# Altium schematic sheet Schem, Transadmittance PWR_rev_D2.SchDoc
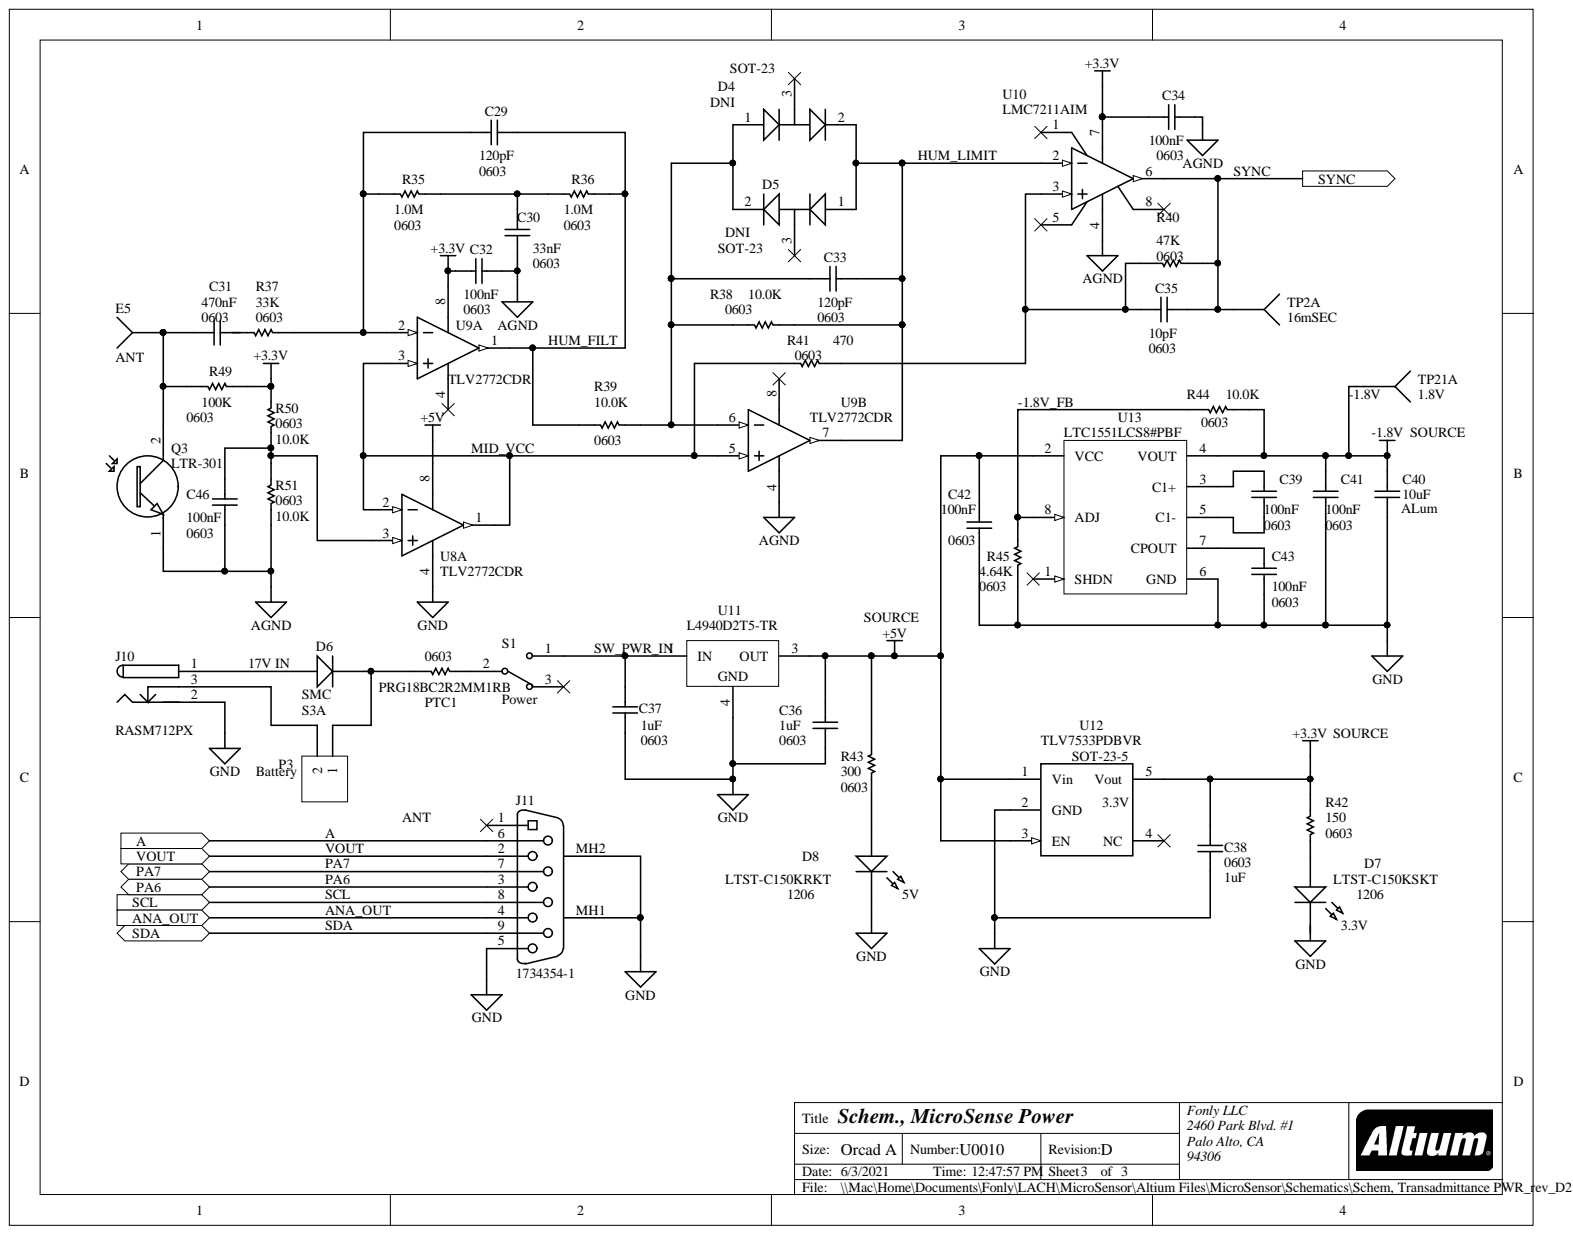
[diagram p0: Schem, Transadmittance PWR_rev_D2.SchDoc]

## Parts
C29  0603  value 120pF  [1608[0603]]
C30  0603  value 33nF  [1608[0603]]
C31  0603  value 470nF  [1608[0603]]
C32  0603  value 100nF  [1608[0603]]
C33  0603  value 120pF  [1608[0603]]
C34  0603  value 100nF  [1608[0603]]
C35  0603  value 10pF  [1608[0603]]
C36  0603  value 1uF  [1608[0603]]
C37  0603  value 1uF  [1608[0603]]
C38  0603  value 1uF  [1608[0603]]
C39  0603  value 100nF  [1608[0603]]
C40  ALum  value 10uF  [1608[0603]]
C41  0603  value 100nF  [1608[0603]]
C42  0603  value 100nF  [1608[0603]]
C43  0603  value 100nF  [1608[0603]]
C46  0603  value 100nF  [1608[0603]]
D4  DNI  Package / Case: SOT-23  [DIOD-SOT23-3_A_V]
D5  DNI  Package / Case: SOT-23  [DIOD-SOT23-3_A_V]
D6  SMC  [SMC]
D7  1206  value 3.3V  MFG_P/N: LTST-C150KSKT  [LTST-C150KSKT_V]
D8  1206  value 5V  MFG_P/N: LTST-C150KRKT  Reverse Voltage: 5V  [LTST-C150KRKT_V]
E5  ANT  [PIN1]
J10  MFG_P/N: RASM712PX  [Switchcraft RASM712]  (hidden comment: Switchcraft RASM712)
J11  1734354-1  [TE-1734354-1-9_V]
P3  Battery  [HDR1X2]
PTC1  0603  MFG_P/N: PRG18BC2R2MM1RB  [RESC1608L]
Q3  LTR-301  [HDR1X2]
R35  0603  value 1.0M  [RESC1608L]
R36  0603  value 1.0M  [RESC1608L]
R37  0603  value 33K  [RESC1608L]
R38  0603  value 10.0K  [RESC1608L]
R39  0603  value 10.0K  [RESC1608L]
R40  0603  value 47K  [RESC1608L]
R41  0603  value 470  [RESC1608L]
R42  0603  value 150  [RESC1608L]
R43  0603  value 300  [RESC1608L]
R44  0603  value 10.0K  [RESC1608L]
R45  0603  value 4.64K  [RESC1608L]
R49  0603  value 100K  [RESC1608L]
R50  0603  value 10.0K  [RESC1608L]
R51  0603  value 10.0K  [RESC1608L]
S1  Power  [G3T12AH]
TP2  16mSEC  [PIN1]  (part A)
TP21  1.8V  [PIN1]  (part A)
U8  TLV2772CDR  [D0008A_N]  (part A)
U9  TLV2772CDR  [D0008A_N]  (parts A,B)
U10  LMC7211AIM  [D0008A_M]
U11  L4940D2T5-TR  [TO-263_L]
U12  SOT-23-5  value 3.3V  MFG_P/N: TLV7533PDBVR  [DBV005]
U13  LTC1551LCS8#PBF  [LT-S8-8_L]

## Ports
A, ANA_OUT, PA6, PA7, SCL, SDA, SYNC, VOUT

## Nets
+3.3V: C32.2 C34.2 C38.1 R42.1 R49.2 R50.1 U9.8 U10.7 U12.5(Vout)
+5V: C36.1 C42.1 R43.1 U8.8 U11.3 U12.1(Vin) U12.3(EN) U13.2(VCC)
AGND: C30.2 C32.1 C34.1 C46.2 Q3.1 R51.2 U9.4 U10.4
GND: C36.2 C37.2 C38.2 C40.1 C41.2 C42.2 C43.2 D8.2 J10.2 J11.5 J11.MH1 J11.MH2 R45.1 U8.4 U11.4 U12.2(GND) U13.6(GND)
17V IN: D6.1 J10.1
-1.8V: C40.2 C41.1 R44.2 TP21.1 U13.4(VOUT)
-1.8V_FB: R44.1 R45.2 U13.8(ADJ)
A: J11.6 ; port A
ANA_OUT: J11.4 ; port ANA_OUT
HUM_FILT: C29.2 R36.1 R39.2 U9.1
HUM_LIMIT: C33.2 D4.2 D5.1 R38.1 U9.7 U10.2
MID_VCC: R41.2 U8.1 U8.2 U9.3 U9.5
PA6: J11.3 ; port PA6
PA7: J11.7 ; port PA7
SCL: J11.8 ; port SCL
SDA: J11.9 ; port SDA
SW_PWR_IN: C37.1 S1.1 U11.1
SYNC: C35.2 R40.2 TP2.1 U10.6 ; port SYNC
VOUT: J11.2 ; port VOUT
NetC29_1: C29.1 R35.2 R37.1 U9.2
NetC30_1: C30.1 R35.1 R36.2
NetC31_2: C31.2 E5.1 Q3.2 R49.1
NetC33_1: C33.1 D4.1 D5.2 R38.2 R39.1 U9.6
NetC35_1: C35.1 R40.1 R41.1 U10.3
NetC39_1: C39.1 U13.3(C1+)
NetC39_2: C39.2 U13.5(C1-)
NetC43_1: C43.1 U13.7(CPOUT)
NetC46_1: C46.1 R50.2 R51.1 U8.3
NetD6_2: D6.2 P3.1 PTC1.1
NetD7_1: D7.1 R42.2
NetD8_1: D8.1 R43.2
NetJ10_3: J10.3 P3.2
NetPTC1_2: PTC1.2 S1.2
ANT:

Not connected: C31 1; D4 3; D5 3; D7 2; J10 2A; J11 1; R37 2; S1 3; U10 1 5 8; U12 4(NC); U13 1(SHDN)

## Library data of the parts
C29 C33 = Cap Semi: Capacitor Ceramic, SMD, 0603, 0.1uF, 16V, 10%; MFG: Samsung; MFG_P/N: C0603C121K5GAC7867; Vendor: DigiKey; Vendor P/N: 399-14288-1-ND; Component Kind: Standard; Library Name: Miscellaneous Devices.SchLib; Signal Integrity: Cap Semi; Simulation: CAP
C30 = Cap Semi: Capacitor Ceramic, SMD, 0603, 0.1uF, 16V, 10%; MFG: Samsung; MFG_P/N: CL10B333KO8NNNC; Vendor: DigiKey; Vendor P/N: 1276-2044-1-ND; Component Kind: Standard; Library Name: Miscellaneous Devices.SchLib; Signal Integrity: Cap Semi; Simulation: CAP
C31 = Cap Semi: Capacitor Ceramic, SMD, 0603, 0.1uF, 16V, 10%; MFG: Samsung; MFG_P/N: CGA3E3X7R1H474M080AB; Vendor: DigiKey; Vendor P/N: 445-181021-1-ND; Component Kind: Standard; Library Name: Miscellaneous Devices.SchLib; Signal Integrity: Cap Semi; Simulation: CAP
C32 = Cap Semi: Capacitor Ceramic, SMD, 0603, 0.1uF, 16V, 10%; MFG: Samsung; MFG_P/N: CL10B104KO8NNWC; Value: 100nF; Vendor: DigiKey; Vendor P/N: 1276-1939-1-ND; Component Kind: Standard; Library Name: Miscellaneous Devices.SchLib; Signal Integrity: Cap Semi; Simulation: CAP
C34 C39 C41-C43 C46 = Cap Semi: Capacitor Ceramic, SMD, 0603, 0.1uF, 16V, 10%; MFG: Samsung; MFG_P/N: CL10B104KO8NNWC; Vendor: DigiKey; Vendor P/N: 1276-1939-1-ND; Component Kind: Standard; Library Name: Miscellaneous Devices.SchLib; Signal Integrity: Cap Semi; Simulation: CAP
C35 = Cap Semi: Capacitor Ceramic, SMD, 0603, 0.1uF, 16V, 10%; MFG: Samsung; MFG_P/N: C0603C100K3GACTU; Vendor: DigiKey; Vendor P/N: 399-8979-1-ND; Component Kind: Standard; Library Name: Miscellaneous Devices.SchLib; Signal Integrity: Cap Semi; Simulation: CAP
C36-C38 = Cap Semi: Capacitor Ceramic, SMD, 0603, .01uF, 16V, 10%; MFG: Kemet; MFG_P/N: C0603C105M3RACTU; Vendor: DigiKey; Vendor P/N: 399-7377-1-ND; Component Kind: Standard; Library Name: Miscellaneous Devices.SchLib; Signal Integrity: Cap Semi; Simulation: CAP
C40 = Cap Semi: Capacitor (Semiconductor SIM Model); MFG: AVX; MFG_P/N: 0603ZD106KAT2A; Vendor: DigiKey; Vendor P/N: 478-10766-1-ND; Component Kind: Standard; Library Name: Miscellaneous Devices.SchLib; Signal Integrity: Cap Semi; Simulation: CAP
D4 D5 = CMP-2000-05931-1: Dual Surface Mount Low Leakage Diode, 1.25 V, -65 to 150 degC, 3-Pin SOT23, RoHS, Tape and Reel; MFG: Diodes Inc.; MFG_P/N: BAV199-7-F; Vendor: DigiKey; Vendor P/N: BAV199-FDICT-ND; Component Kind: Standard; Library Name: Altium Content Vault; Package Reference: SOT23-3; Series: BAV199
D6 = Diode: Diode, 3A 50 PIV, SMC; MFG: On Semi; MFG_P/N: S3A; Vendor: DigiKey; Vendor P/N: S3AFSCT-ND; Component Kind: Standard; Library Name: Miscellaneous Devices.SchLib; Signal Integrity: Diode; Simulation: DIODE
D7 = CMP-2000-06618-1: LED Yellow, 75 mW, 80 mA, -55 to 85 degC, 2-Pin SMD, RoHS, Tape and Reel; Manufacturer: Lite-On; Dominant Wave Length: 587nm; Emitting Color: Amber; Forward Current: 30mA; Forward Voltage: 2.4V; High Brightness: Yes; Luminous Intensity: 60mcd; Main Category: ChipLED; MFG: Vishay Liteon; Number of  Elements: 1; Peak Wavelength: 588nm; Power Dissipation: 75W; Product Depth (mm): 1.6mm; Product Height (mm): 1.1mm; Product Length (mm): 3.2mm; Rad Hardened: No; Reverse Voltage: 5V; Shape Type: Rectangular; Test Current (It): 20mA; Vendor: DigiKey; Vendor P/N: 160-1406-1-ND; Component Kind: Standard; Datasheet URL: http://optoelectronics.liteon.com/upload/download/DS-22-99-0187/S_110_LTST-C150KSKT.pdf; Lens Dimensions: 2.06X1.6X0.6mm; Library Name: Altium Content Vault; Lum Intensity: 60mcd; Manufacturer URL: http://www.us.liteon.com/; Mounting: SurfaceMount; Mounting Technology: SurfaceMount; Operating Temperature Classification: Industrial; Operating Temp Range: -55Cto85C; Package Description: 2-Pin Surface Mount Device, Body 3.2 x 1.6 mm; Package Reference: C150KSKT; Package Type: 1206; Pin Count: 2
D8 = CMP-2000-06617-1: LED Red, 62.5 mW, 50 mA, -30 to 85 degC, 2-Pin SMD, RoHS, Tape and Reel; Manufacturer: Lite-On; Chip Material: AlGaInP; DELETED: Compliant; Dominant Wave Length: 631nm; Forward Current: 30mA; Forward Voltage: 2.4V; High Brightness: Yes; Luminous Intensity: 54mcd; Main Category: ChipLED; MFG: Vishay Liteon; Number of  Elements: 1; Peak Wavelength: 639nm; Power Dissipation: 75W; Product Depth (mm): 1.6mm; Product Height (mm): 1.1mm; Product Length (mm): 3.2mm; Rad Hardened: No; Shape Type: Rectangular; Test Current (It): 20mA; Type: Uni-Color; Vendor: DigiKey; Vendor P/N: 160-1405-1-ND; Viewing Angle: 130deg; Component Kind: Standard; Datasheet URL: http://optoelectronics.liteon.com/upload/download/DS-22-99-0149/S_110_LTST-C150KRKT.pdf; Datasheet Version: Rev. C, 01/2013; Emitting Color: Red; Lens Dimensions: 2.06X1.6X0.6mm; Library Name: Altium Content Vault; Lum Intensity: 54mcd; Manufacturer URL: http://www.us.liteon.com/; Mounting: SurfaceMount; Mounting Technology: SurfaceMount; Operating Temperature Classification: Industrial; Operating Temp Range: -55Cto85C; Package Description: 2-Pin Surface Mount Device, Body 3.2 x 1.6 mm; Package Reference: C150KRKT; Package Type: ChipLed; Packaging: TapeandReel; Pin Count: 2; Vensor P/N: LTST-C150KRKT
E5 TP2 TP21 = Socket: Component Kind: Standard; Description: Socket; LatestRevisionDate: 17-Jul-2002; LatestRevisionNote: Re-released for DXP Platform.; Library Name: Current Miscellaneous Connectors.SchLib; Signal Integrity: Connector; Publisher: Altium Limited
J10 = Switchcraft RASM712: Recp., Pwr, coaxial, barrel, 2.5/2.1mm pin, RASM; Vendor: DigiKey; Vendor P/N: RASM712PX-ND; Component Kind: Standard; Library Name: Current Miscellaneous Connectors.SchLib; MFG: Switchcraft
J11 = CMP-2000-05403-1: D-Subminiature Socket, Pitch 1.385 mm, 9 Position, Height 12.5 mm, Tail Length 3.2 mm, RoHS, Tray; Manufacturer: TE Connectivity; 3D Model: 1734354-1.pdf; Catalog Drawings: AMPD-SubMale.318; Connector Style: D-Sub; Connector Type: Receptacle,FemaleSockets; Current Rating: 2A; Dynamic Catalog: AMPLIMITEHD-20Series; Featured Product: AMPLIMITED-SubminiatureConnectors; Flange Feature: MatingSide;FemaleScrewlock(4-40); Mating Products: AML09B-ND  -CONND-SUBPLUG9POSGOLD; MFG: TE AMP; Number of Positions: 9; Other Names: A35107; Product Training Modules: AMPLIMITED-SubConnectors; Vendor: DigiKey; Vendor P/N: A35107-ND; Voltage Rating: 250V; Category: Connectors,Interconnects; Color: Black; Component Kind: Standard; Contact Finish: Gold; Contact Finish Thickness: Flash; Contact Type: Signal; Datasheet URL: http://www.te.com.cn/chn-zh/search.html?q=1734354-1&source=header#pdp-optional-registration; Datasheet Version: Rev. A1, 03/2011; Family: D-SubConnectors; Features: BoardLock; Housing Material: Polyamide(PA9T),Nylon9T,GlassFilled; Library Name: Altium Content Vault; Manufacturer URL: http://www.te.com/; MFG_P/N: 1734354-1; Mounting Type: ThroughHole,RightAngle; Number of Rows: 2; Operating Temperature: -55degC~105degC; RoHS Information: 1734354-1StatementofCompliance; Series: AMPLIMITEHD-20; Shell Material, Finish: Steel,NickelPlated; Shell Size, Connector Layout: 1(DE,E); Standard Package: 90; Termination: Solder
P3 = Header 2: Header, 2-Pin, M, 0.1"; MFG: TE AMP; MFG_P/N: 87224-2; Vendor: DigiKey; Vendor P/N: A26543-ND; Component Kind: Standard; LatestRevisionDate: 17-Jul-2002; LatestRevisionNote: Re-released for DXP Platform.; Library Name: Current Miscellaneous Connectors.SchLib; Signal Integrity: Connector; Publisher: Altium Limited
PTC1 = Res1: PTC thermistor - 155mA hold I, 800mA.; MFG: Murata; Value: PTC_2R2; Vendor: DigiKey; Vendor P/N: 490-8507-1-ND; Component Kind: Standard; Library Name: Miscellaneous Devices.SchLib; Signal Integrity: Res; Simulation: RESISTOR
Q3 = Photo NPN: NPN Phototransistor; MFG: LiteOn; Vendor: DigiKey; Vendor P/N: 160-1065-ND; Component Kind: Standard; Library Name: Miscellaneous Devices.SchLib; MFG_P/N: LTR-301
R35 R36 = Res1: Resistor; MFG: Panasonic; MFG_P/N: ERJ-3EKF1004V; Vendor: DigiKey; Vendor P/N: P1.00MHCT-ND; Component Kind: Standard; Library Name: Miscellaneous Devices.SchLib; Signal Integrity: Res; Simulation: RESISTOR
R37 = Res1: Resistor; MFG: Panasonic; MFG_P/N: ERJ-3EKF3302V; Vendor: DigiKey; Vendor P/N: P33.0KHCT-ND; Component Kind: Standard; Library Name: Miscellaneous Devices.SchLib; Signal Integrity: Res; Simulation: RESISTOR
R38 R49 = Res1: Resistor; MFG: Panasonic; MFG_P/N: ERJ-3EKF1003V; Vendor: DigiKey; Vendor P/N: P100KHCT-ND; Component Kind: Standard; Library Name: Miscellaneous Devices.SchLib; Signal Integrity: Res; Simulation: RESISTOR
R39 R44 R50 R51 = Res1: Resistor; MFG: Panasonic; MFG_P/N: ERJ-3EKF1002V; Vendor: DigiKey; Vendor P/N: P10.0KHCT-ND; Component Kind: Standard; Library Name: Miscellaneous Devices.SchLib; Signal Integrity: Res; Simulation: RESISTOR
R40 = Res1: Resistor; MFG: Panasonic; MFG_P/N: ERJ-3EKF4702V; Value: 47K; Vendor: DigiKey; Vendor P/N: P47.0KHCT-ND; Component Kind: Standard; Library Name: Miscellaneous Devices.SchLib; Signal Integrity: Res; Simulation: RESISTOR
R41 = Res1: Resistor; MFG: Panasonic; MFG_P/N: ERJ-3EKF4701V; Vendor: DigiKey; Vendor P/N: P4.70KHCT-ND; Component Kind: Standard; Library Name: Miscellaneous Devices.SchLib; Signal Integrity: Res; Simulation: RESISTOR
R42 = Res1: Resistor; MFG: Panasonic; MFG_P/N: ERJ-3EKF1500V; Vendor: DigiKey; Vendor P/N: P150HCT-ND; Component Kind: Standard; Library Name: Miscellaneous Devices.SchLib; Signal Integrity: Res; Simulation: RESISTOR
R43 = Res1: Resistor; MFG: Panasonic; MFG_P/N: ERJ-3EKF3000V; Vendor: DigiKey; Vendor P/N: P300HCT-ND; Component Kind: Standard; Library Name: Miscellaneous Devices.SchLib; Signal Integrity: Res; Simulation: RESISTOR
R45 = Res1: Resistor; MFG: Panasonic; MFG_P/N: ERJ-PA3F4641V; Vendor: DigiKey; Vendor P/N: 667-ERJ-PA3F4641V; Component Kind: Standard; Library Name: Miscellaneous Devices.SchLib; Signal Integrity: Res; Simulation: RESISTOR
S1 = SW-SPDT: SPDT Subminiature Toggle Switch, Right Angle Mounting, Vertical Actuation; MFG: NTT; MFG_P/N: G3T12AH-R; Vendor: DigiKey; Vendor P/N: 360-1773-1-ND; Component Kind: Standard; ComponentLink1Description: Manufacturer Link; ComponentLink1URL: http://www.apem.com; DatasheetVersion: 05-Dec-2000; LatestRevisionDate: 17-Dec-2003; Library Name: Miscellaneous Devices.SchLib
U8 = CMP-0272-03014-3: Dual High-Slew-Rate Rail-to-Rail Output Operational Amplifier, 2.5 to 6 V, 0 to 70 degC, 8-pin SOIC (D8), Green (RoHS & no Sb/Br); MFG: TI; Vendor: DigiKey; Vendor P/N: 296-10677-1-ND; Component Kind: Standard; ComponentLink1Description: Manufacturer URL; ComponentLink1URL: http://www.ti.com/; ComponentLink2Description: Datasheet; ComponentLink2URL: http://www.ti.com/lit/ds/symlink/tlv2772.pdf; ComponentLink3Description: Package Specification; ComponentLink3URL: http://www.ti.com/litv/pdf/msoi002j; Library Name: Altium Content Vault; MFG_P/N: TLV2772CDR
U9 = CMP-0272-03014-3: Dual High-Slew-Rate Rail-to-Rail Output Operational Amplifier, 2.5 to 6 V, 0 to 70 degC, 8-pin SOIC (D8), Green (RoHS & no Sb/Br); CMRR(Min)(dB): 60; GBW(Typ)(MHz): 4.8; Iq per channel(Max)(mA): 2; MFG: TI; Packing: LARGE T&R; Rating: Catalog; Slew Rate(Typ)(V/us): 9; Sub Family: Low Supply Voltage Amplifier (<=2.7V), Precision Amplifier; Total Supply Voltage(Max)(+5V is 5, +/-5V is 10): 6; Total Supply Voltage(Min)(+5V is 5, +/-5V is 10): 2.5; Vendor: DigiKey; Vendor P/N: 296-10677-1-ND; Code_JEDEC: MS-012; Component Kind: Standard; ComponentLink1Description: Manufacturer URL; ComponentLink1URL: http://www.ti.com/; ComponentLink2Description: Datasheet; ComponentLink2URL: http://www.ti.com/lit/ds/symlink/tlv2772.pdf; ComponentLink3Description: Package Specification; ComponentLink3URL: http://www.ti.com/litv/pdf/msoi002j; DatasheetVersion: SLOS209G; Eco-Plan: Green (RoHS & no Sb/Br); IIB(Max)(pA): 60; Library Name: Altium Content Vault; MFG_P/N: TLV2772CDR; Mounting Technology: Surface Mount; Number of Channels: 2; Offset Drift(Typ)(uV/C): 2; PackageDescription: 8-Pin Plastic Small Outline, Body 4.9 x 3.9 mm, Pitch 1.27 mm; PackageReference: D0008A; PackageVersion: 4040047-3/M, 06/2011; PartNumber: TLV2772CDR; Vio (25C)(Max)(mV): 2.5
U10 = CMP-0055-00407-3: Tiny CMOS Comparator with Rail-to-Rail Input, 8-pin Narrow SOIC; Manufacturer: Texas Instruments; CompType: Amplifiers; MFG: TI; MFG_P/N: LMC7211AIMX/NOPB; PackageTopMarking: NSZXTT*LMC72*11AIM; PowerWise: N; Vendor: DigiKey; Vendor P/N: 296-LMC7211AIMX/NOPBCT-ND; Code_IPC: SOIC127P600-8; Code_JEDEC: MS-012-AA; Component Kind: Standard; ComponentLink1Description: Datasheet URL; ComponentLink1URL: http://www.ti.com/general/docs/lit/getliterature.tsp?genericPartNumber=LMC7211-N&fileType=pdf; Library Name: Altium Content Vault; PackageDescription: SOIC Narrow, 4.9 x 3.9 x 1.45mm, 8 Lead, 1.27mm Pitch; Package Reference: D0008A; PinCount: 8; RoHS: N
U11 = CMP-0244-00196-1: 1.5A Very Low Drop Regulator, 3-Pin D2PAK, Tape and Reel; MFG: STM; Value: 5V; Vendor: DigiKey; Vendor P/N: 497-1166-1-ND; Component Kind: Standard; ComponentLink1Description: Manufacturer URL; ComponentLink1URL: http://www.st.com; ComponentLink2Description: Datasheet; ComponentLink2URL: http://www.st.com/internet/com/TECHNICAL_RESOURCES/TECHNICAL_LITERATURE/DATASHEET/CD00000442.pdf; DatasheetVersion: 16/12/2009; Library Name: Altium Content Vault; MFG_P/N: L4940D2T5-TR; PackageDescription: 3-Pin Transistor Outline Package 2.54 mm Pitch, 15.4 mm Lead Span (D2PAK); PackageReference: TO-263; PackageVersion: 2011
U12 = TLV755: IC, Voltage Regulator, Linear LDO, SOT-23-5; MFG: TI; Vendor: DigiKey; Vendor P/N: 296-50411-1-ND; Component Kind: Standard; Library Name: TI Power Mgt Voltage Regulator.IntLib
U13 = CMP-0475-00442-1: Low Noise, Switched Capacitor Regulated Voltage Inverter, 2.7 to 5.25 V Vin, Adjustable Vout, 8-pin SOIC (S8-8), 0 to 70 degC, Pb-Free; Manufacturer: Linear Technology; MFG: Analog Devices; Vendor: DigiKey; Vendor P/N: LTC1551LCS8#PBF-ND; Component Kind: Standard; ComponentLink1Description: Manufacturer URL; ComponentLink1URL: http://www.linear.com/; ComponentLink2Description: Datasheet; ComponentLink2URL: http://cds.linear.com/docs/en/datasheet/15501lf.pdf; ComponentLink3Description: Package Specification; ComponentLink3URL: http://cds.linear.com/docs/en/packaging/05081610_G_SO8.pdf; Library Name: Altium Content Vault; MFG_P/N: LTC1551LCS8#PBF; PartNumber: LTC1551LCS8#PBF
